# Altium schematic sheet Sheet3.SchDoc(Sheet3)
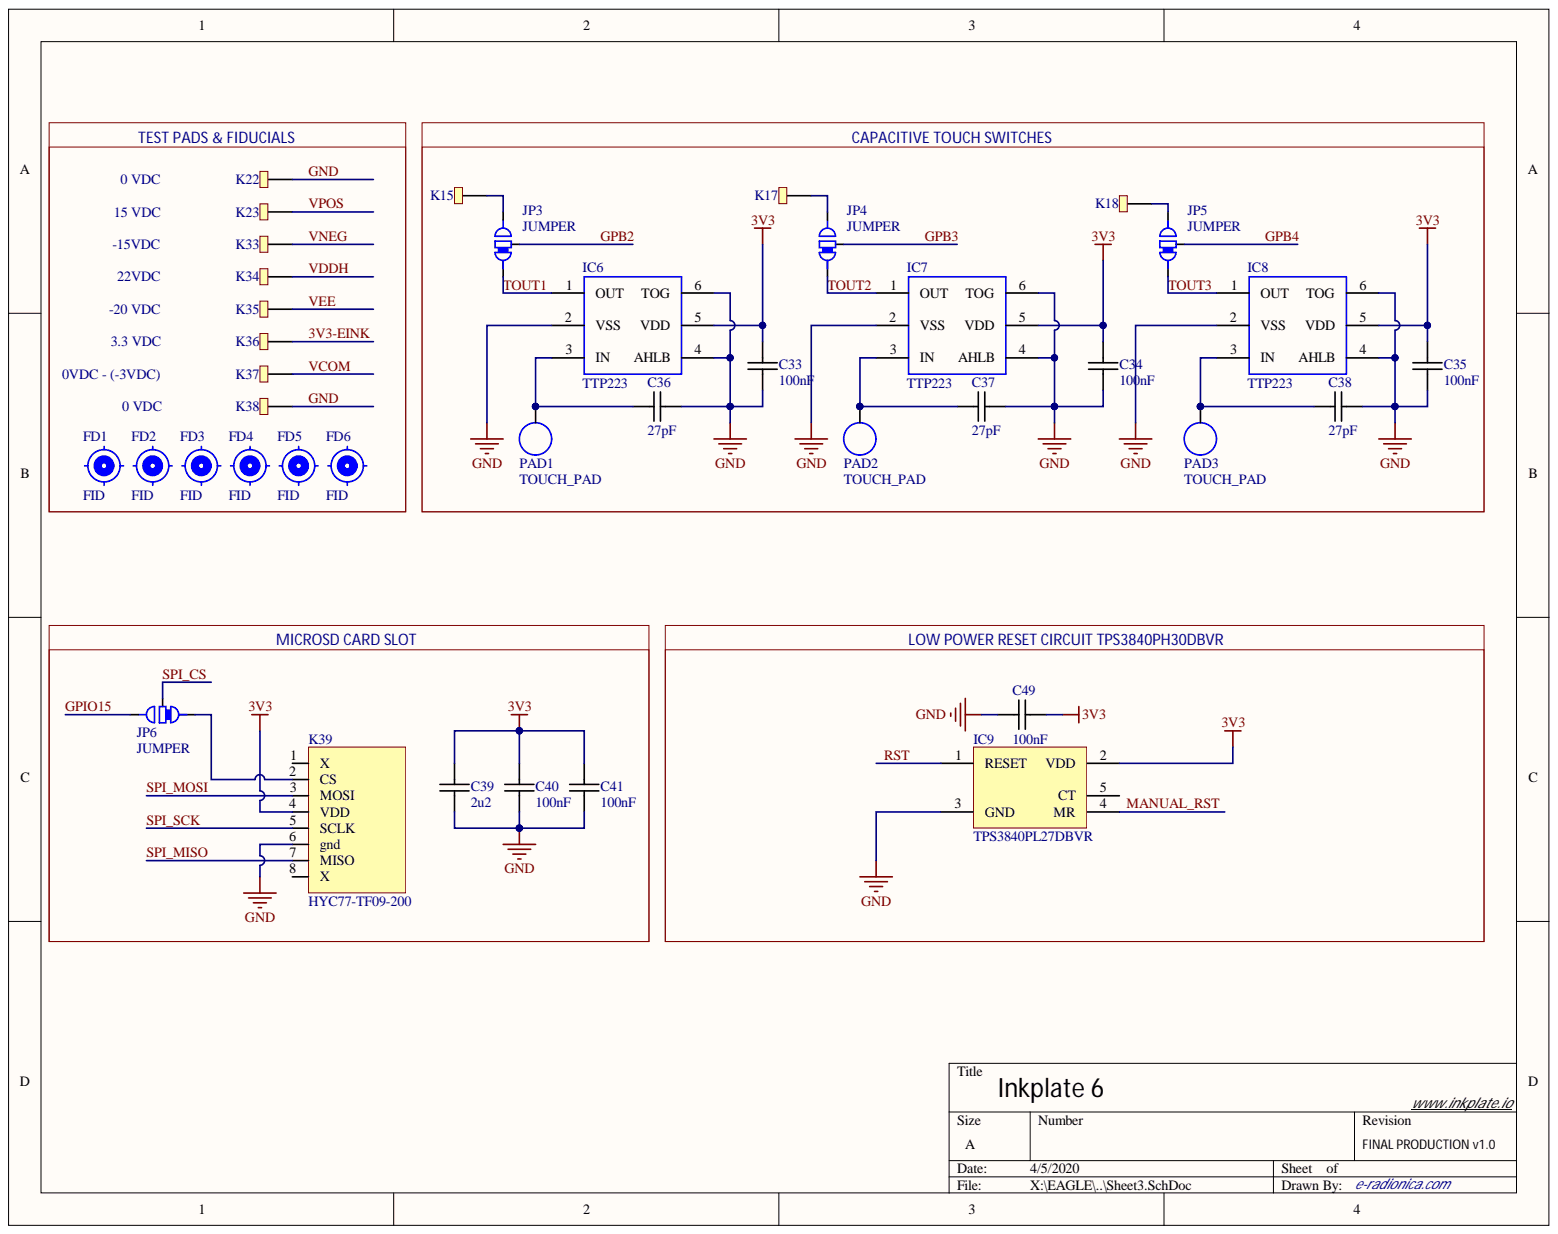
[diagram p0: Sheet3.SchDoc]

## Parts
C33  100nF  [0603C-GENERIC]
C34  100nF  [0603C-GENERIC]
C35  100nF  [0603C-GENERIC]
C36  27pF  [0603C-GENERIC]
C37  27pF  [0603C-GENERIC]
C38  27pF  [0603C-GENERIC]
C39  2u2  [0603C-2U2]
C40  100nF  [0603C-GENERIC]
C41  100nF  [0603C-GENERIC]
C49  100nF  [0603C-GENERIC]
FD1  FID  [FIDU-ROUND]  (no BOM)
FD2  FID  [FIDU-ROUND]  (no BOM)
FD3  FID  [FIDU-ROUND]  (no BOM)
FD4  FID  [FIDU-ROUND]  (no BOM)
FD5  FID  [FIDU-ROUND]  (no BOM)
FD6  FID  [FIDU-ROUND]  (no BOM)
IC6  TTP223  [SOT95P285X126-6N_TTP223]
IC7  TTP223  [SOT95P285X126-6N_TTP223]
IC8  TTP223  [SOT95P285X126-6N_TTP223]
IC9  TPS3840PL27DBVR  [TPS3840PH30DBVR]
JP3  JUMPER  [SMD Jumper3 Closed]
JP4  JUMPER  [SMD Jumper3 Closed]
JP5  JUMPER  [SMD Jumper3 Closed]
JP6  JUMPER  [SMD Jumper3 Closed]
K15  HEADER-1x1  [PAD-1x1]  (value not drawn)
K17  HEADER-1x1  [PAD-1x1]  (value not drawn)
K18  HEADER-1x1  [PAD-1x1]  (value not drawn)
K22  HEADER-1x1  [PAD-1x1]  (value not drawn)
K23  HEADER-1x1  [PAD-1x1]  (value not drawn)
K33  HEADER-1x1  [PAD-1x1]  (value not drawn)
K34  HEADER-1x1  [PAD-1x1]  (value not drawn)
K35  HEADER-1x1  [PAD-1x1]  (value not drawn)
K36  HEADER-1x1  [PAD-1x1]  (value not drawn)
K37  HEADER-1x1  [PAD-1x1]  (value not drawn)
K38  HEADER-1x1  [PAD-1x1]  (value not drawn)
K39  HYC77-TF09-200  [HYC77-TF09-200]
PAD1  TOUCH_PAD  [TOUCH PAD]
PAD2  TOUCH_PAD  [TOUCH PAD]
PAD3  TOUCH_PAD  [TOUCH PAD]

## Nets
3V3: C33.1 C34.1 C35.1 C39.1 C40.1 C41.1 C49.2 IC6.5(VDD) IC7.5(VDD) IC8.5(VDD) IC9.2(VDD) K39.4(VDD)
3V3-EINK: K36.1
GND: C33.2 C34.2 C35.2 C36.2 C37.2 C38.2 C39.2 C40.2 C41.2 C49.1 IC6.2(VSS) IC6.4(AHLB) IC6.6(TOG) IC7.2(VSS) IC7.4(AHLB) IC7.6(TOG) IC8.2(VSS) IC8.4(AHLB) IC8.6(TOG) IC9.3(GND) K22.1 K38.1 K39.6(gnd)
GPB2: JP3.2
GPB3: JP4.2
GPB4: JP5.2
GPIO15: JP6.3
MANUAL_RST: IC9.4(MR)
RST: IC9.1(RESET)
SPI_CS: JP6.2
SPI_MISO: K39.7(MISO)
SPI_MOSI: K39.3(MOSI)
SPI_SCK: K39.5(SCLK)
TOUT1: IC6.1(OUT) JP3.1
TOUT2: IC7.1(OUT) JP4.1
TOUT3: IC8.1(OUT) JP5.1
VCOM: K37.1
VDDH: K34.1
VEE: K35.1
VNEG: K33.1
VPOS: K23.1
NetC36_1: C36.1 IC6.3(IN) PAD1.1
NetC37_1: C37.1 IC7.3(IN) PAD2.1
NetC38_1: C38.1 IC8.3(IN) PAD3.1
NetJP3_3: JP3.3 K15.1
NetJP4_3: JP4.3 K17.1
NetJP5_3: JP5.3 K18.1
NetJP6_1: JP6.1 K39.2(CS)

Not connected: IC9 5(CT); K39 1(X) 8(X)

## Library data of the parts
C33-C35 C40 C41 C49 = 0603C-100NF-25V-X7R-TDK: CAPACITOR 0603 CERAMIC; Manufacturer Part Number: C1608X7R1E104K; Manufacturer: TDK Corporation; Reel: C12; Supplier Part Number 1: 445-1316-2-ND; Supplier 1: Digi-Key; Library Name: vh_altium_library.intLib; Component Kind: Standard
C36-C38 = 0603C-27pF: CAPACITOR 0603 CERAMIC; REEL: C98; Supplier Part Number 1: 445-1274-2-ND; Supplier 1: Digi-Key; Library Name: vh_altium_library.intLib; Component Kind: Standard
C39 = 0603C-2U2-6V3-X5R-MUR: CAPACITOR 0603 CERAMIC; Reel: C20; Library Name: vh_altium_library.intLib; Component Kind: Standard
FD1-FD6 = FIDU-ROUND: ROUND FIDUCIAL; Library Name: vh_altium_library.intLib
IC9 = TPS3840PH30DBVR: Library Name: Schlib17052019.SchLib; Component Kind: Standard
JP3-JP6 = SMD_JUMPER3_CLOSED: Component Kind: Standard; Library Name: eink_library.IntLib
K15 K17 K18 K22 K23 K33-K38 = HEADER-1x1: HEADER 1x1; Component Kind: Standard; Library Name: eink_library.IntLib
IC6-IC8 = TTP223: Component Kind: Standard; Library Name: eink_library.IntLib
K39 = HYC77-TF09-200: Library Name: Schlib17052019.SchLib; Component Kind: Standard
PAD1-PAD3 = TOUCH_PAD: Component Kind: Standard; Library Name: eink_library.IntLib
